# Altium schematic sheet OnePhaseMain.SchDoc
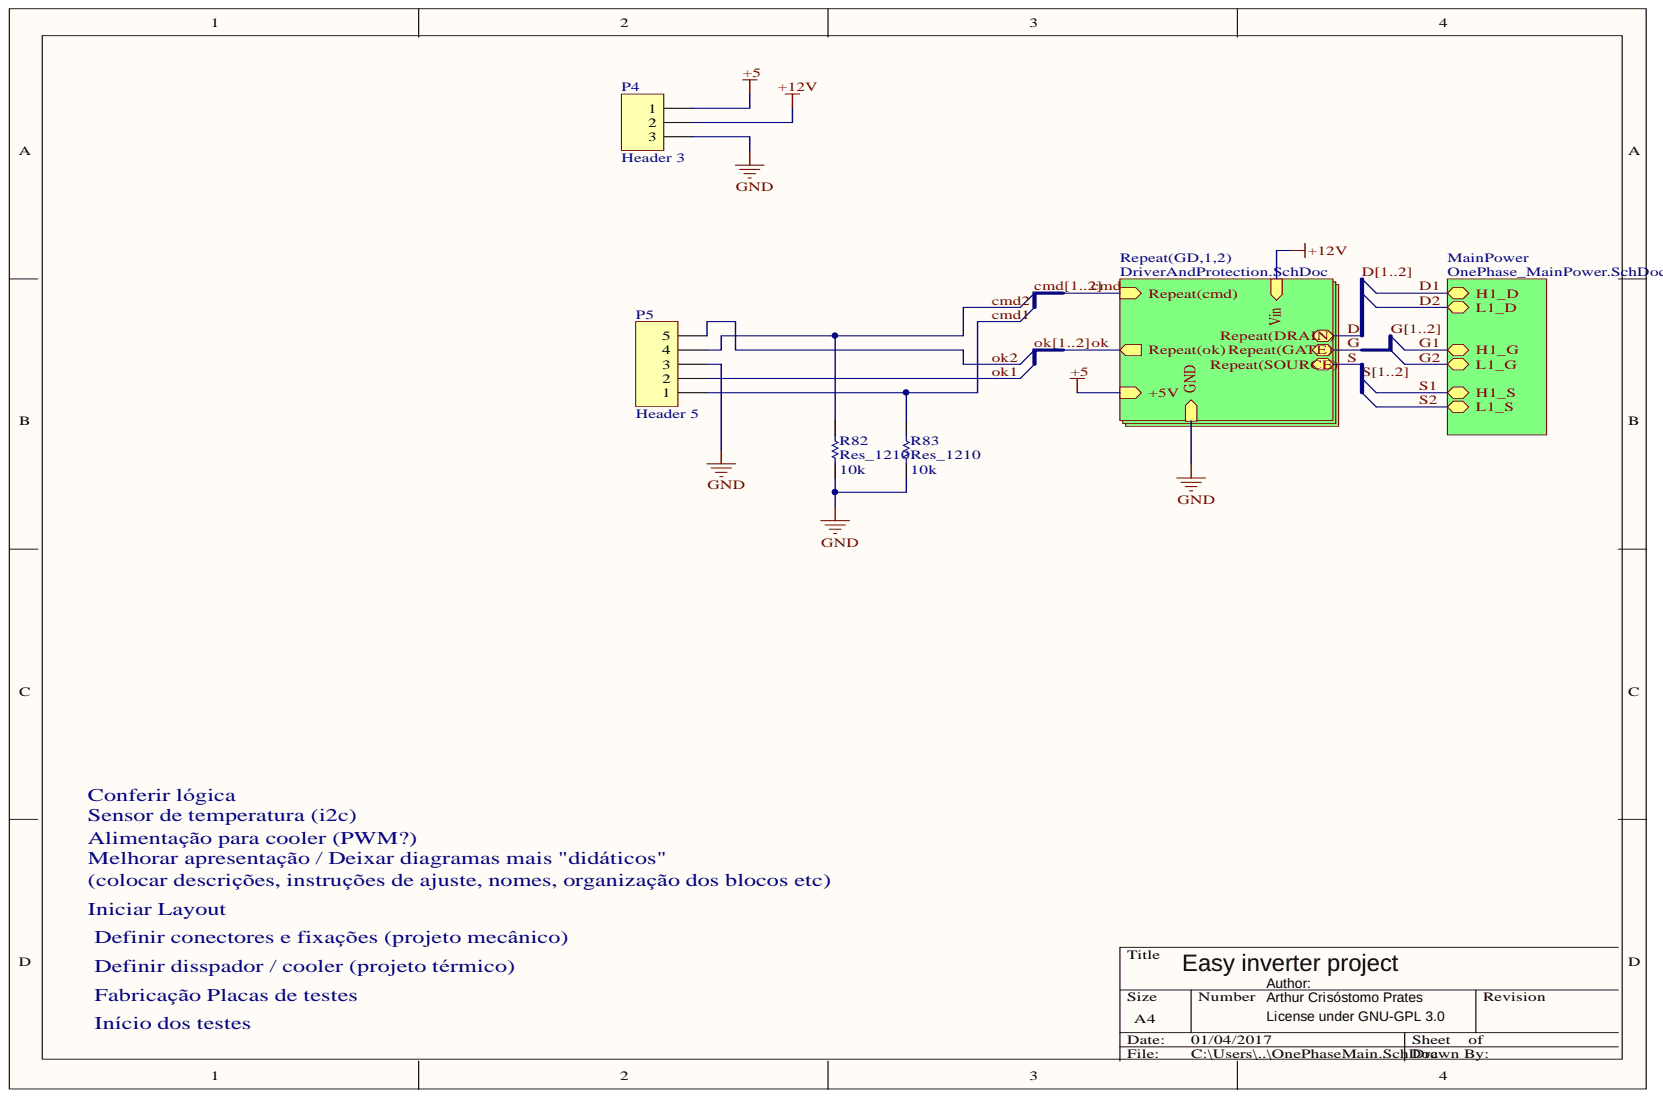
[diagram p0: OnePhaseMain.SchDoc]

## Parts
P4  Header 3  [HDR1X3]
P5  Header 5  [HDR1X5]
R82  Res_1210  value 10k  [0805_W]
R83  Res_1210  value 10k  [0805_W]

## Nets
+5: P4.1
+12V: P4.2
GND: P4.3 P5.3 R82.2 R83.2
CMD1: P5.1 R83.1  [also labelled CMD]
CMD2: P5.4 R82.1  [also labelled CMD]
OK1: P5.2  [also labelled OK]
OK2: P5.5  [also labelled OK]
D1:  [also labelled D]
D2:  [also labelled D]
G1:  [also labelled G]
G2:  [also labelled G]
S1:  [also labelled S]
S2:  [also labelled S]

## Library data of the parts
P4 = Header 3: Header, 3-Pin; Component Kind: Standard; LatestRevisionDate: 17-Jul-2002; LatestRevisionNote: Re-released for DXP Platform.; Library Name: Miscellaneous Connectors.IntLib; Signal Integrity: Connector; Publisher: Altium Limited
P5 = Header 5: Header, 5-Pin; Component Kind: Standard; LatestRevisionDate: 17-Jul-2002; LatestRevisionNote: Re-released for DXP Platform.; Library Name: Miscellaneous Connectors.IntLib; Signal Integrity: Connector; Publisher: Altium Limited
R82 R83 = Res_0805: Resistor; Manufacturer: Generic Components; Class: Passive; Component Kind: Standard; Published: 08/06/2000; Signal Integrity: Res1; Simulation: RESISTOR; SubClass: Resistor; Library Name: Inversor_LCEE.SchLib; Publisher: Altium Hobart Technology Centre
